# Altium schematic sheet Mechanical and Interconnect.SchDoc
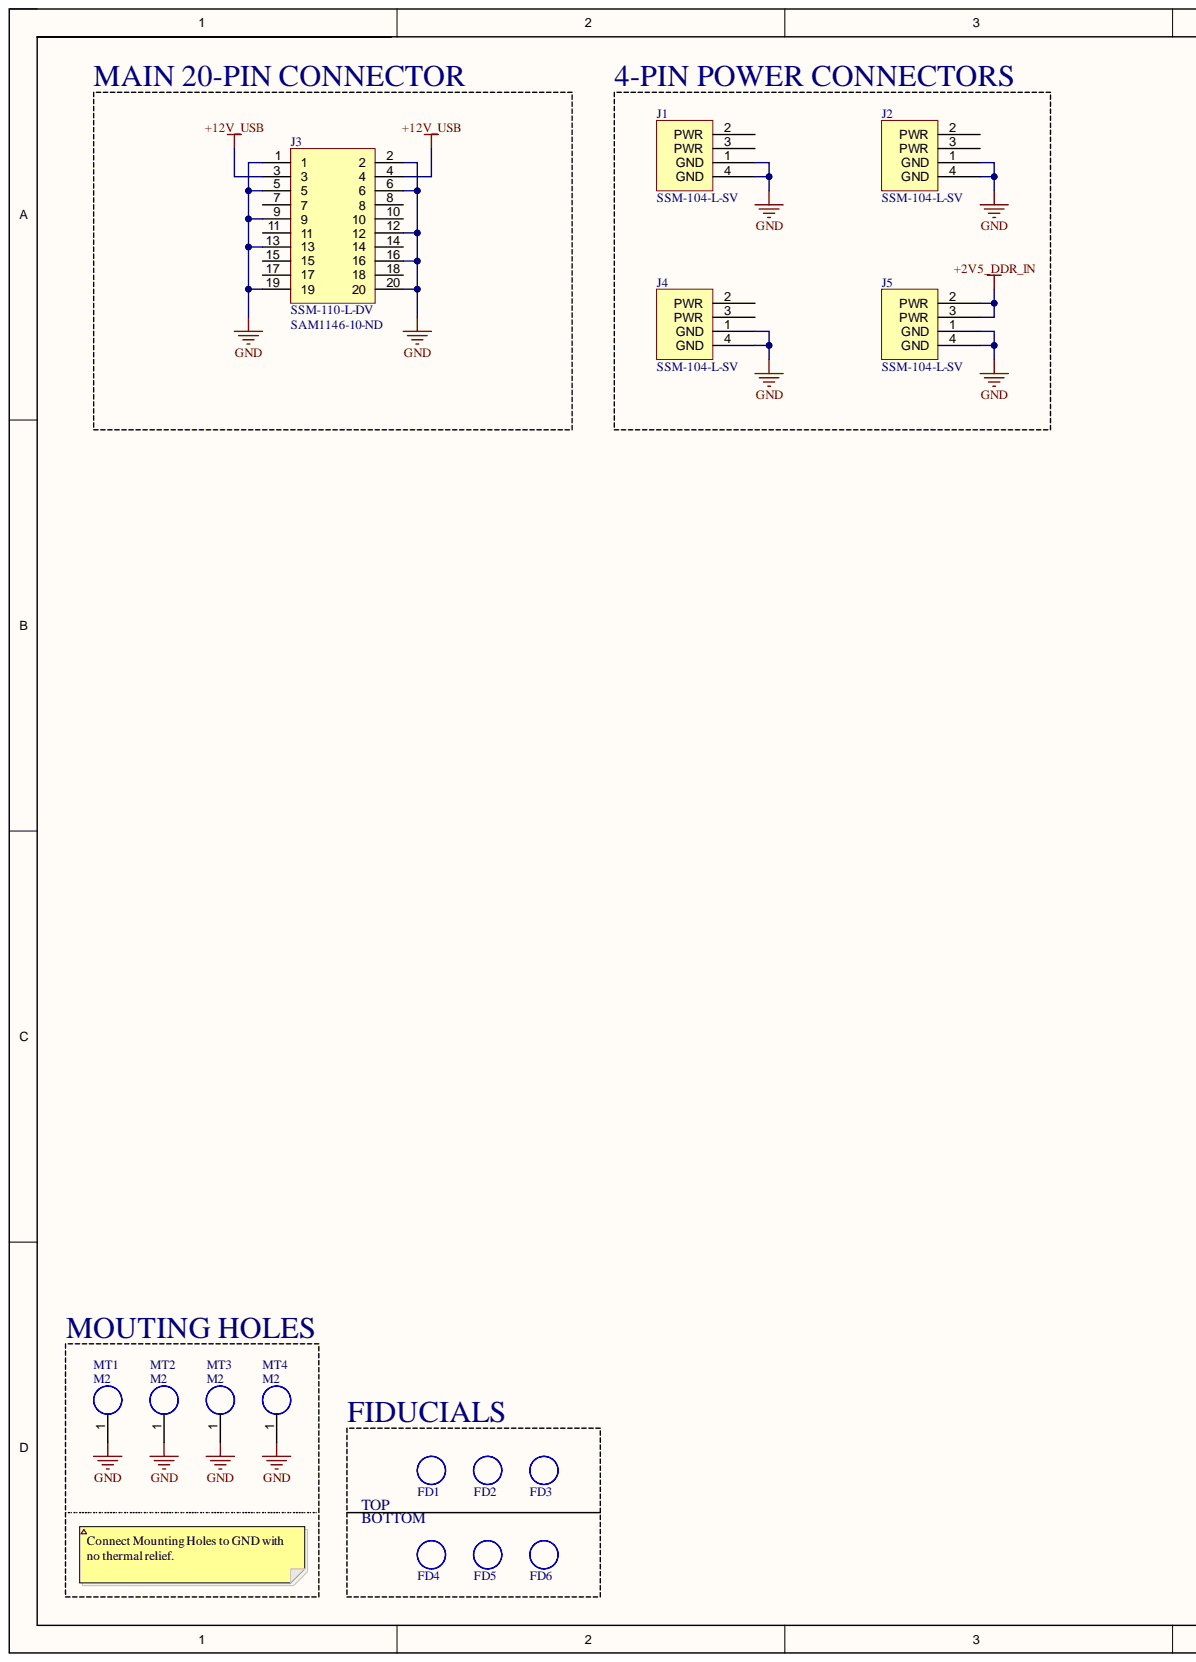
[diagram p0: Mechanical and Interconnect.SchDoc, left]
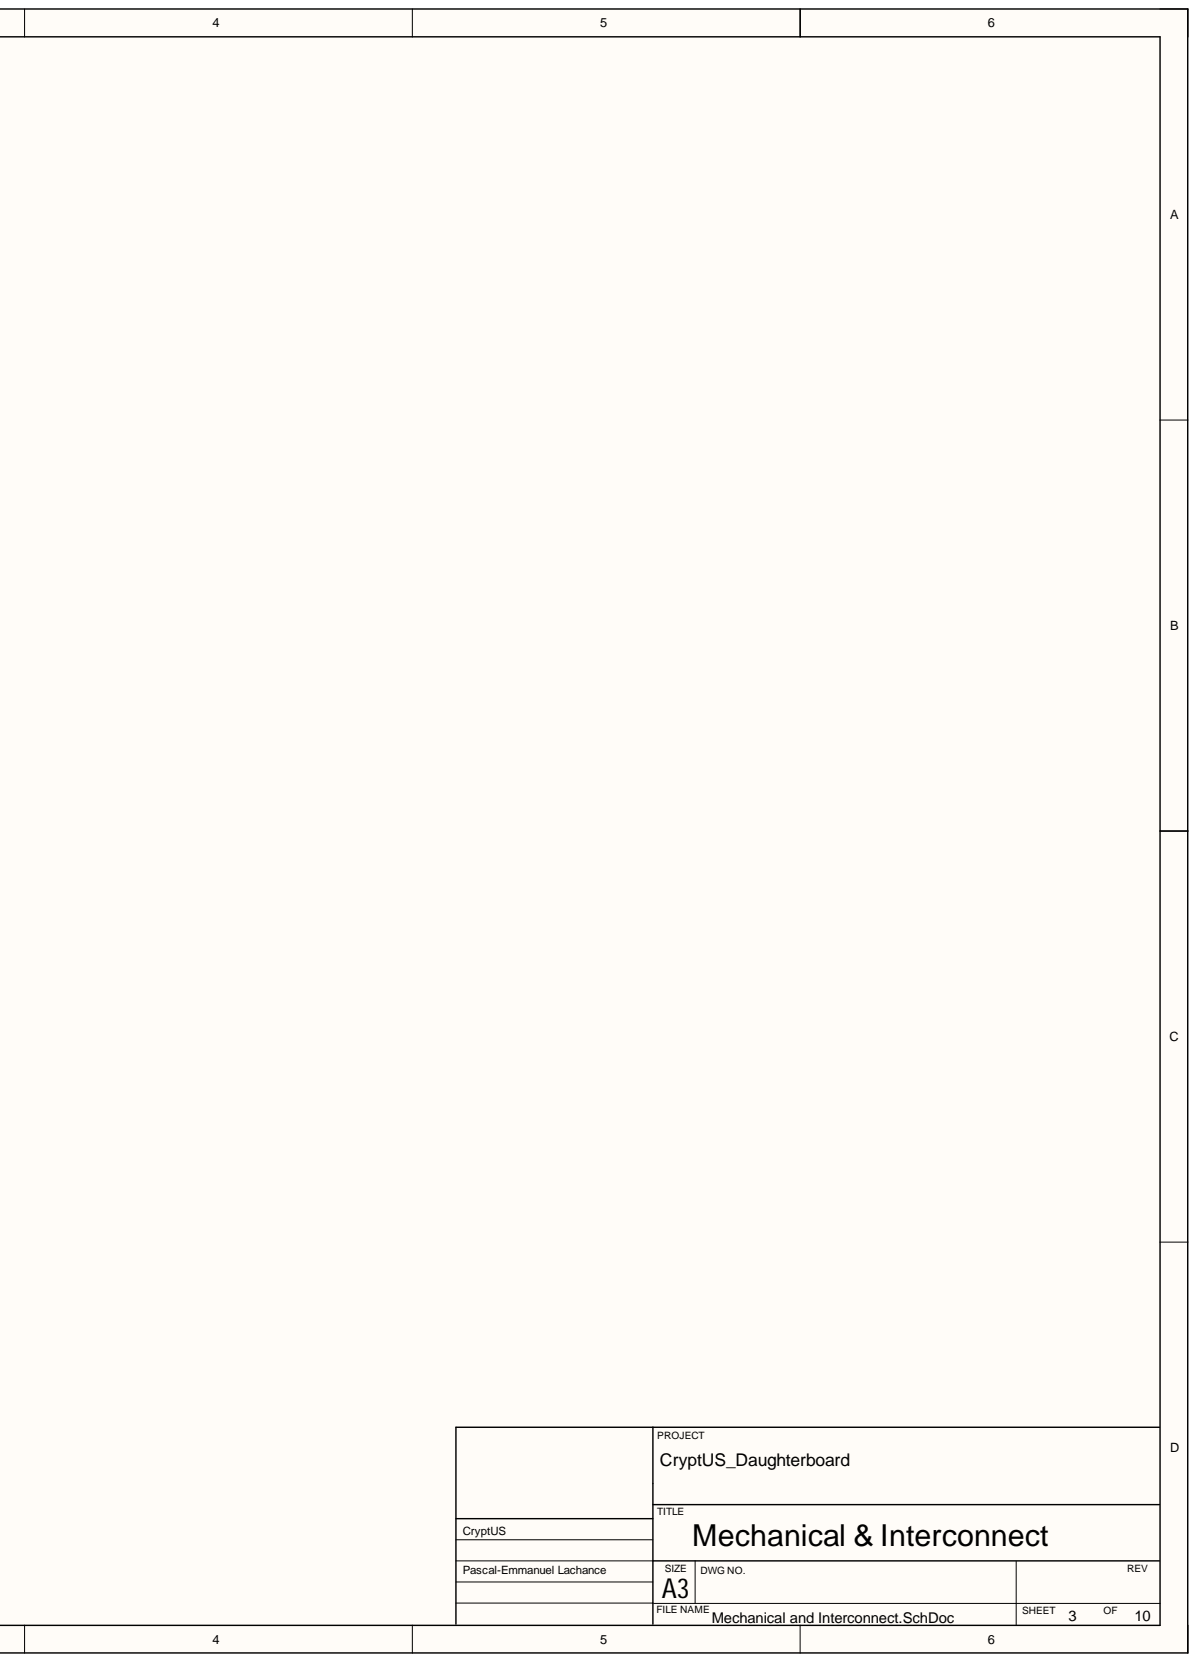
[diagram p1: Mechanical and Interconnect.SchDoc, right]

## Parts
FD1  FIDUCIAL  [FIDUCIAL]
FD2  FIDUCIAL  [FIDUCIAL]
FD3  FIDUCIAL  [FIDUCIAL]
FD4  FIDUCIAL  [FIDUCIAL]
FD5  FIDUCIAL  [FIDUCIAL]
FD6  FIDUCIAL  [FIDUCIAL]
J1  SSM-104-L-SV  [SSM-104-L-SV]
J2  SSM-104-L-SV  [SSM-104-L-SV]
J3  SSM-110-L-DV  Supplier Part Number 1: SAM1146-10-ND  [SSM-110-L-DV]
J4  SSM-104-L-SV  [SSM-104-L-SV]
J5  SSM-104-L-SV  [SSM-104-L-SV]
MT1  M2  [MTH_M2]
MT2  M2  [MTH_M2]
MT3  M2  [MTH_M2]
MT4  M2  [MTH_M2]

## Nets
+2V5_DDR_IN: J5.2(PWR) J5.3(PWR)
+12V_USB: J3.3 J3.4
GND: J1.1(GND) J1.4(GND) J2.1(GND) J2.4(GND) J3.1 J3.2 J3.5 J3.6 J3.9 J3.12 J3.13 J3.16 J3.19 J3.20 J4.1(GND) J4.4(GND) J5.1(GND) J5.4(GND) MT1.1 MT2.1 MT3.1 MT4.1

Not connected: J1 2(PWR) 3(PWR); J2 2(PWR) 3(PWR); J3 7 8 10 11 14 15 17 18; J4 2(PWR) 3(PWR)

## Library data of the parts
J1 J2 J4 J5 = CONN_SSM-104-L-SV: CONN RCPT 4POS 0.1 GOLD SMD; Mfr: Samtec Inc.; Current: 5.2A / contact; Number of positions: 4; Pitch: 100mil; Component Kind: Standard; Insulation Height: 290mil; Operating Temperature: -55C - 125C; Library Name: CryptLib.IntLib
J3 = CONN_SSM-110-L-DV: Mfr: Samtech Inc.; Current Rating: 5.2A per contact; Number of positions: 20; Pitch: 100mils; Voltage Rating: 405VAC, 572VDC; Component Kind: Standard; Insulation Height: 290mils; Mounting Type: Surface Mount; Number of rows: 2; Operating Temperature: -55C - 125C; Supplier 1: Digi-Key; Library Name: CryptLib.IntLib
MT1-MT4 = MOUNTING_HOLE: Component Kind: Standard; Library Name: RaesLib.IntLib
FD1-FD6 = FIDUCIAL: Component Kind: Standard; Library Name: Symbols.SchLib
